# Altium schematic sheet Power.SchDoc
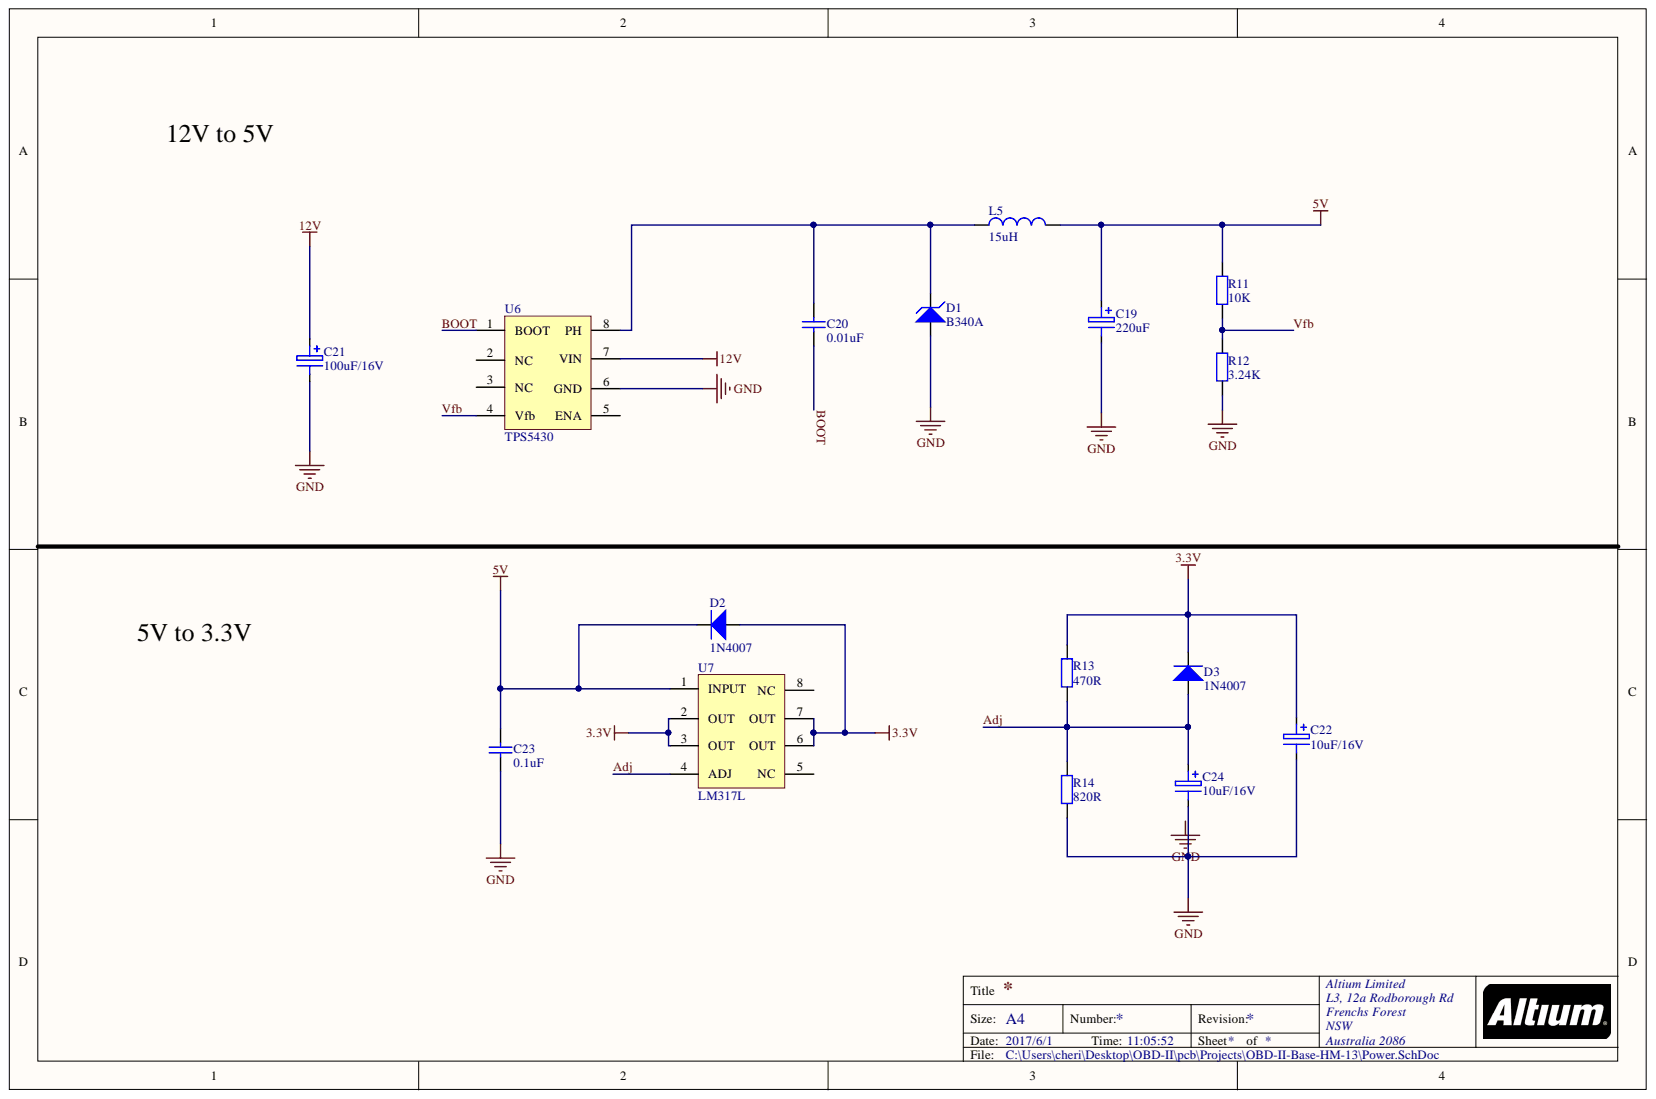
[diagram p0: Power.SchDoc]

## Parts
C19  220uF  [CAPM-7.0*4.5]
C20  0.01uF  [0603]
C21  100uF/16V  [CAPM-7.0*4.5]
C22  10uF/16V  [CAPM-5.5*3.3]
C23  0.1uF  [0603]
C24  10uF/16V  [CAPM-5.5*3.3]
D1  B340A  [DO214-AC]
D2  1N4007  [DO214-AC]
D3  1N4007  [DO214-AC]
L5  15uH  [7*7*4-15uH]
R11  10K  [0603]
R12  3.24K  [0603]
R13  470R  [0603]
R14  820R  [0603]
U6  TPS5430  [TPS5430]
U7  LM317L  [LM317L]

## Nets
3.3V: C22.1 D2.1 D3.2 R13.2 U7.2(OUT) U7.3(OUT) U7.6(OUT) U7.7(OUT)
5V: C19.1 C23.2 D2.2 L5.2 R11.2 U7.1(INPUT)
12V: C21.1 U6.7(VIN)
GND: C19.2 C21.2 C22.2 C23.1 C24.2 D1.1 R12.1 R14.1 U6.6(GND)
ADJ: C24.1 D3.1 R13.1 R14.2 U7.4(ADJ)
BOOT: C20.1 U6.1(BOOT)
VFB: R11.1 R12.2 U6.4(Vfb)
NetC20_2: C20.2 D1.2 L5.1 U6.8(PH)

Not connected: U6 2(NC) 3(NC) 5(ENA); U7 5(NC) 8(NC)

## Library data of the parts
C19 C21 C22 C24 = Cap3: aluminum; Component Kind: Standard; Library Name: ToponLib.IntLib
C20 C23 = Cap1: ceramic; Component Kind: Standard; Library Name: ToponLib.IntLib
D1 = B340A-13-F: Component Kind: Standard; Library Name: ToponLib.IntLib
L5 = Inductor: Component Kind: Standard; Library Name: ToponLib.IntLib
R11-R14 = Res: Component Kind: Standard; Library Name: ToponLib.IntLib
D2 D3 = 1N4007: Component Kind: Standard; Library Name: ToponLib.IntLib
U6 = TPS5430: Component Kind: Standard; Library Name: ToponLib.IntLib
U7 = LM317L: Component Kind: Standard; Library Name: ToponLib.IntLib
